# Altium schematic sheet pmFAKEFAN.SchDoc(pmFAKEFAN)
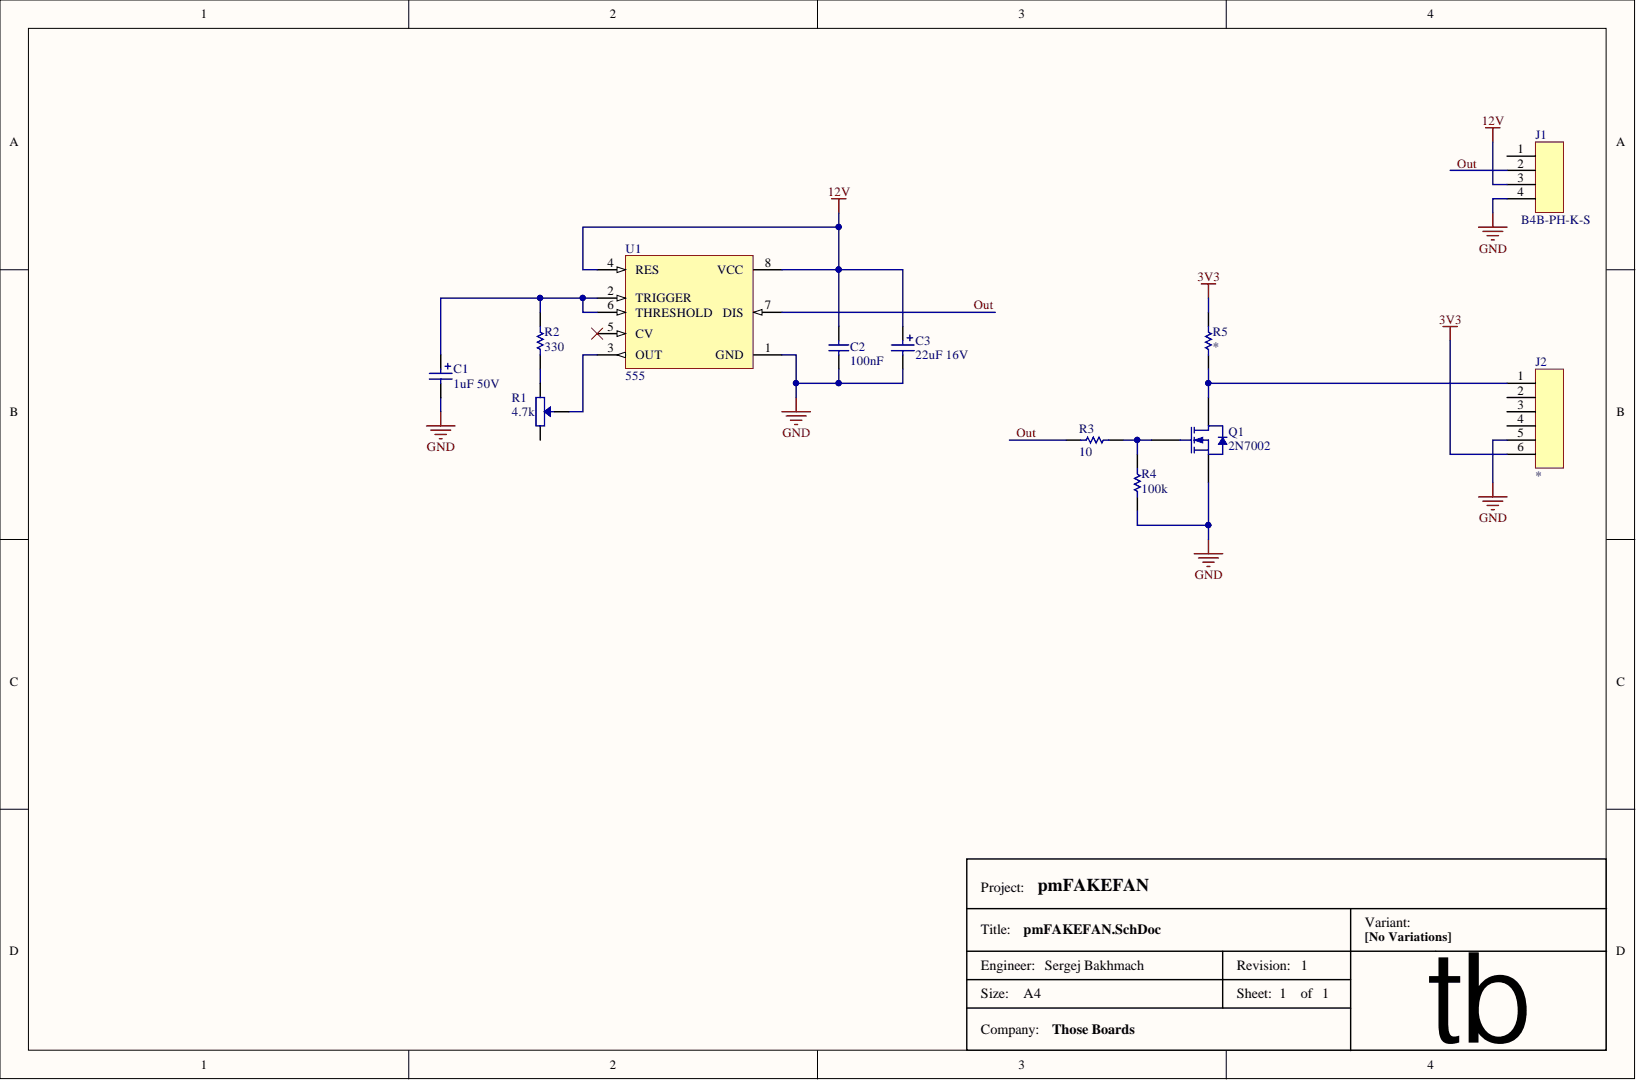
[diagram p0: pmFAKEFAN.SchDoc]

## Parts
C1  value 1uF 50V  [CAPAE6553X55M]  (hidden comment: Capacitor)
C2  value 100nF  [C0603]  (hidden comment: Capacitor)
C3  value 22uF 16V  [CAPAE6553X55M]  (hidden comment: Capacitor)
J1  B4B-PH-K-S  [B4B-PH-K-S Horizontal]
J2  (no value)  [CONN_1x6_2.54_RA_PMOD]
Q1  2N7002  [SOT23-3]
R1  value 4.7k  [3296W Horizontal]  (hidden comment: Resistor)
R2  330  [0805_resistor]
R3  10  [0805_resistor]
R4  100k  [0805_resistor]
R5  (no value)  [0805_resistor]
U1  555  [SO8]

## Nets
3V3: J2.6 R5.2
12V: C2.2 C3.1 J1.3 U1.4(RES) U1.8(VCC)
GND: C1.2 C2.1 C3.2 J1.4 J2.5 Q1.2 R4.1 U1.1(GND)
Out: J1.2 R3.2 U1.7(DIS)
NetC1_1: C1.1 R2.1 U1.2(TRIGGER) U1.6(THRESHOLD)
NetJ2_1: J2.1 Q1.3 R5.1
NetQ1_1: Q1.1 R3.1 R4.2
NetR1_1: R1.1 R2.2
NetR1_2: R1.2 U1.3(OUT)

Not connected: J1 1; J2 2 3 4; R1 3; U1 5(CV)

## Library data of the parts
C1 C3 = Cap_Alum_Pol: Component Kind: Standard; Library Name: thoseboards.IntLib
C2 = Cap: Component Kind: Standard; Library Name: thoseboards.IntLib
J1 = Conn_1x4: Connector; Manufacturer: JST; Value: B4B-PH-K-S; Manufacturer Part: B4B-PH-K-S; Component Kind: Standard; Library Name: thoseboards.IntLib
J2 = conn_PMOD 6p: PLS-6 2.54mm Pitch; Component Kind: Standard; Library Name: thoseboards.IntLib
Q1 = 2N7002: Transistor MOSFET N-CHANNEL 60V 115mA; Value: 2N7002; Component Kind: Standard; Library Name: thoseboards.IntLib
R1 = Res_var: Component Kind: Standard; Library Name: thoseboards.IntLib
R2-R5 = Res: Resistor; Component Kind: Standard; Library Name: thoseboards.IntLib
  R2: Value: 330
  R3: Value: 10
  R4: Value: 100k
U1 = 555: Single Timer; Value: 555; Component Kind: Standard; Library Name: thoseboards.IntLib
